# Altium schematic sheet Audio Power Amplifier.SchDoc("Audio Power Amplifier")
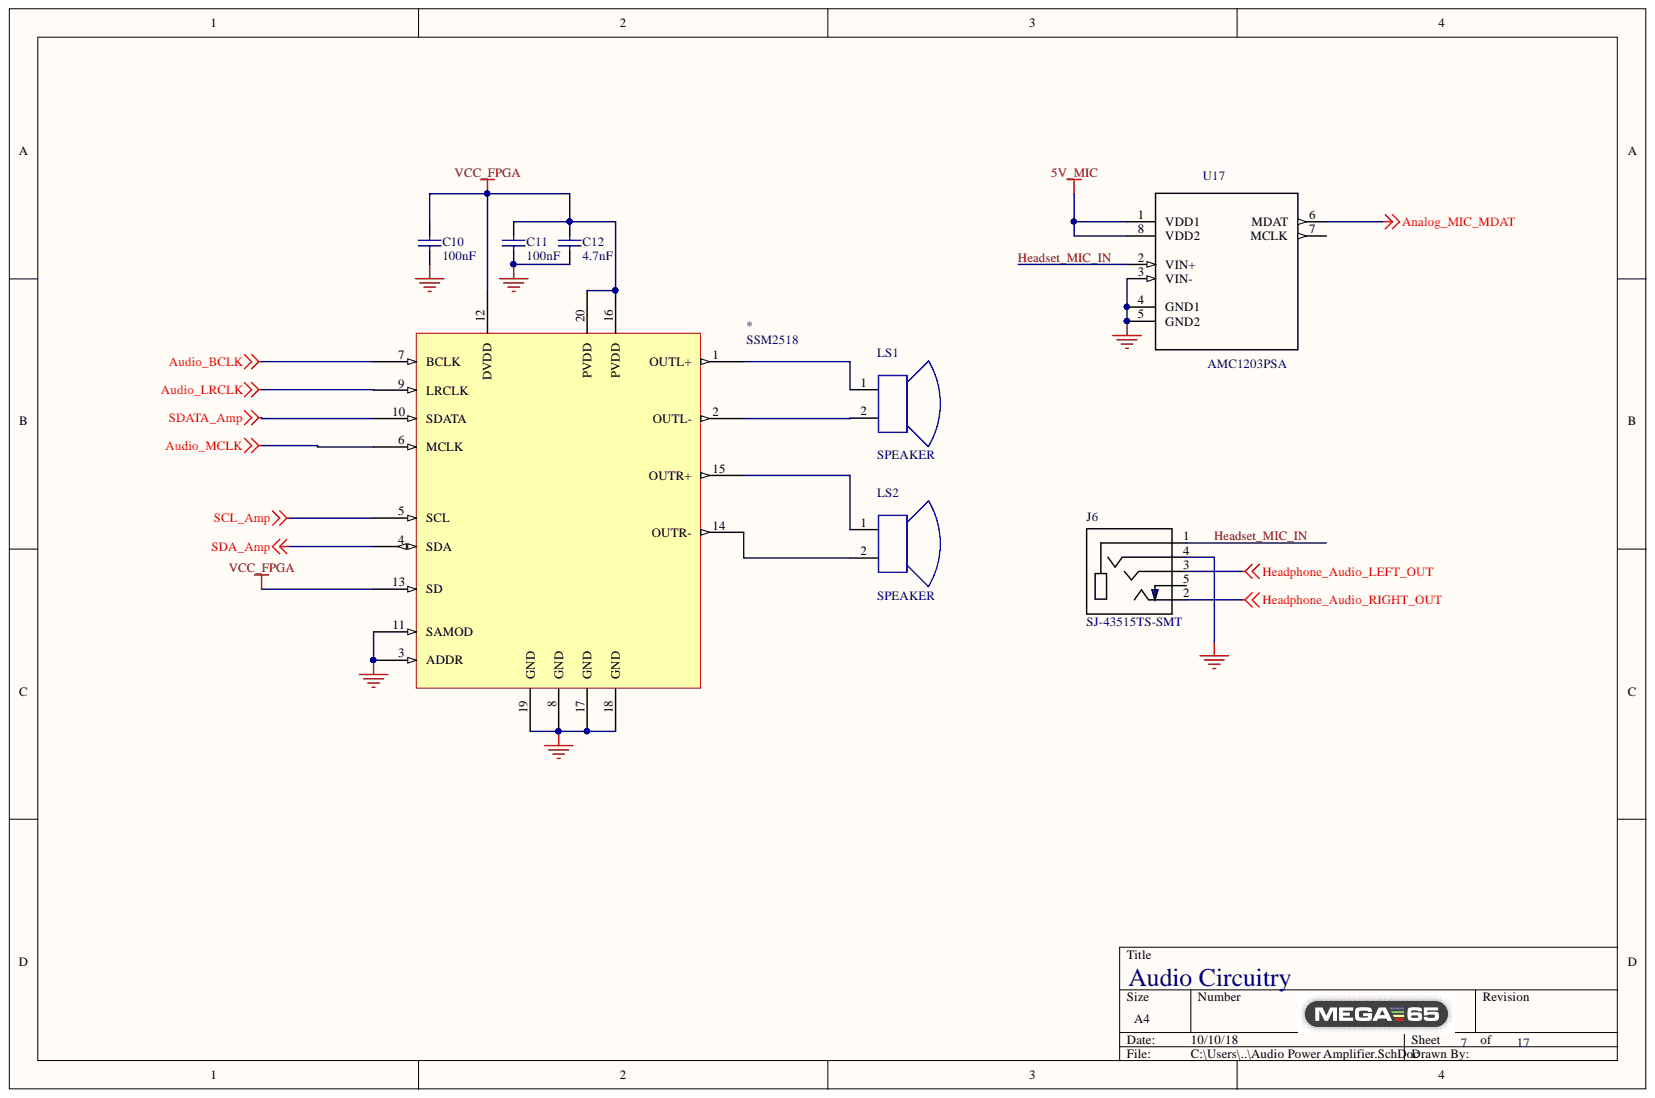
[diagram p0: Audio Power Amplifier.SchDoc]

## Parts
*  SSM2518  [QFN50P400X400X100-21N]
C10  100nF  [CAPC0805(2012)100_N]
C11  100nF  [CAPC0805(2012)100_N]
C12  4.7nF  [CAPC0805(2012)100_N]
J6  SJ-43515TS-SMT  [CUI_SJ-43515TS-SMT]
LS1  SPEAKER
LS2  SPEAKER
U17  AMC1203PSA  [SOIC127P790X200-8N]

## Nets
5V_MIC: U17.1(VDD1) U17.8(VDD2)
Analog_MIC_MDAT: U17.6(MDAT)
Audio_BCLK: *.7(BCLK)
Audio_LRCLK: *.9(LRCLK)
Audio_MCLK: *.6(MCLK)
GND: *.3(ADDR) *.8(GND) *.11(SAMOD) *.17(GND) *.18(GND) *.19(GND) C10.1 C11.1 C12.1 J6.4 U17.3(VIN-) U17.4(GND1) U17.5(GND2)
Headphone_Audio_LEFT_OUT: J6.3
Headphone_Audio_RIGHT_OUT: J6.2
SCL_Amp: *.5(SCL)
SDA_Amp: *.4(SDA)
SDATA_Amp: *.10(SDATA)
VCC_FPGA: *.12(DVDD) *.13(SD) *.16(PVDD) *.20(PVDD) C10.2 C11.2 C12.2
Headset_MIC_IN: J6.1 U17.2(VIN+)
Net*_1: *.1(OUTL+) LS1.1
Net*_2: *.2(OUTL-) LS1.2
Net*_14: *.14(OUTR-) LS2.2
Net*_15: *.15(OUTR+) LS2.1

Not connected: J6 5; U17 7(MCLK)

## Library data of the parts
C10-C12 = CMP-1036-01531-1: CAP 39pF 100V ±2% 0805 (2012 Metric) Thickness 1mm SMD; Tolerance: Â±2%; Case-Metric: 2012; Case-EIA: 0805; Value: 39pF; Technology: SMT; Rated Voltage: 100 V; Max Thickness: 1 mm; Library Name: Altium Content Vault; Component Kind: Standard
J6 = SJ-43515TS-SMT: SJ Series 3.5 mm 16 V 4 Conductor SMT Mid Mount Stereo Audio Jack; MP: SJ-43515TS-SMT-TR; MF: CUI; SnapEDA_Link: https://www.snapeda.com/parts/SJ-43515TS-SMT-TR/CUI/view-part/?ref=snap; Price: 0.70 USD; Package: None; Library Name: SJ-43515TS-SMT.IntLib; Component Kind: Standard; Availability: Good
LS1 LS2 = SPEAKER-2: Library Name: Schlib1.SchLib; Component Kind: Standard
U17 = AMC1203PSA: Single Channel Single ADC Delta-Sigma 40ksps 16-bit Serial 8-Pin SOP Tube; MF: Texas Instruments; SnapEDA_Link: https://www.snapeda.com/parts/AMC1203PSA/Texas%20Instruments/view-part/?ref=snap; Package: SOP-8 Texas Instruments; MP: AMC1203PSA; Library Name: AMC1203PSA.IntLib; Digi-Key_Purchase_URL: https://www.digikey.com.au/product-detail/en/texas-instruments/AMC1203PSA/296-27654-5-ND/2346561?utm_source=snapeda&utm_medium=aggregator&utm_campaign=symbol; Digi-Key_Part_Number: 296-27654-5-ND; Component Kind: Standard
* = SSM2518: Library Name: Schlib1.SchLib; Component Kind: Standard
